# Altium schematic sheet Sheet1.SchDoc
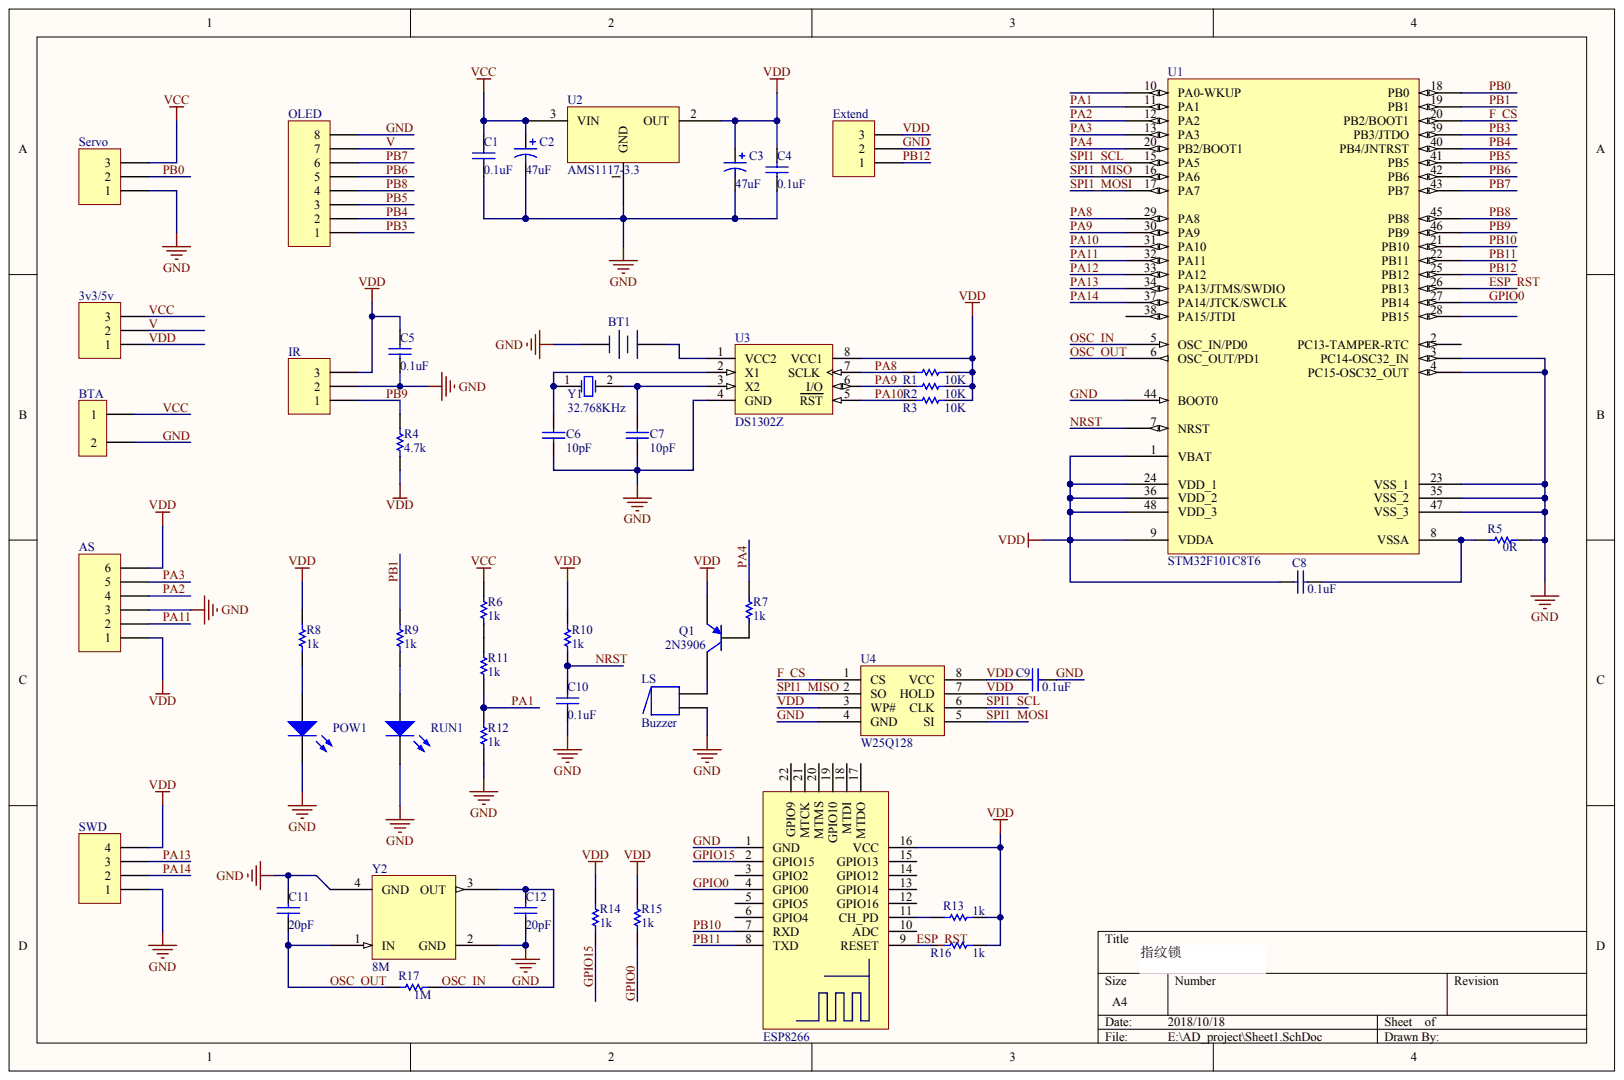
[diagram p0: Sheet1.SchDoc]

## Parts
1  ESP8266
3v3/5v  (no value)
AS  ATK-AS608  (value not drawn)
BT1  Battery  (value not drawn)
BTA  BTA
C1  Öµ: 0.1uF  (hidden comment: Cap 1)
C2  value 47uF  (hidden comment: Cap Pol3)
C3  value 47uF  (hidden comment: Cap Pol3)
C4  Öµ: 0.1uF  (hidden comment: Cap 1)
C5  Öµ: 0.1uF
C6  Öµ: 10pF  (hidden comment: Cap 1)
C7  Öµ: 10pF  (hidden comment: Cap 1)
C8  Öµ: 0.1uF  (hidden comment: Cap 1)
C9  Öµ: 0.1uF  (hidden comment: Cap 1)
C10  Öµ: 0.1uF
C11  Öµ: 20pF
C12  Öµ: 20pF
Extend  (no value)
IR  红外接收  (value not drawn)
LS  Buzzer
OLED  OLED
POW1  LED  (value not drawn)
Q1  2N3906
R1  Öµ: 10K  (hidden comment: Res 1)
R2  Öµ: 10K  (hidden comment: Res 1)
R3  Öµ: 10K  (hidden comment: Res 1)
R4  Öµ: 4.7k  (hidden comment: Res 1)
R5  Öµ: 0R  (hidden comment: Res 1)
R6  Öµ: 1k  (hidden comment: Res 1)
R7  Öµ: 1k  (hidden comment: Res 1)
R8  Öµ: 1k  (hidden comment: Res 1)
R9  Öµ: 1k  (hidden comment: Res 1)
R10  Öµ: 1k  (hidden comment: Res 1)
R11  Öµ: 1k  (hidden comment: Res 1)
R12  Öµ: 1k  (hidden comment: Res 1)
R13  Öµ: 1k  (hidden comment: Res 1)
R14  Öµ: 1k  (hidden comment: Res 1)
R15  Öµ: 1k  (hidden comment: Res 1)
R16  Öµ: 1k  (hidden comment: Res 1)
R17  Öµ: 1M  (hidden comment: Res 1)
RUN1  LED  (value not drawn)
Servo  (no value)
SWD  SWD
U1  STM32F101C8T6
U2  AMS1117-3.3
U3  DS1302Z
U4  W25Q128
Y1  Öµ: 32.768KHz  (hidden comment: XTAL)
Y2  8M

## Nets
ESP_RST: 1.9(RESET) R16.1 U1.26(PB13)
F_CS: U1.20 U4.1(CS)
GND: 1.1(GND) AS.3 BT1.2 BTA.2 C1.1 C2.2 C3.2 C4.1 C5.2 C6.1 C7.1 C9.2 C10.2 C11.1 C12.2 Extend.2 IR.2 LS.2 OLED.8 POW1.2 R5.2 R12.2 RUN1.2 Servo.1 SWD.1 U1.3(PC14-OSC32_IN) U1.4(PC15-OSC32_OUT) U1.23(VSS_1) U1.35(VSS_2) U1.44(BOOT0) U1.47(VSS_3) U2.1 U3.4(GND) U4.4(GND) Y2.2(GND) Y2.4(GND)
GPIO0: 1.4(GPIO0) R15.2 U1.27(PB14)
GPIO15: 1.2(GPIO15) R14.2
NRST: C10.1 R10.2 U1.7(NRST)
OSC_IN: C12.1 R17.2 U1.5(OSC_IN/PD0) Y2.3(OUT)
OSC_OUT: C11.2 R17.1 U1.6(OSC_OUT/PD1) Y2.1(IN)
PA1: R11.2 R12.1 U1.11(PA1)
PA2: AS.4 U1.12(PA2)
PA3: AS.5 U1.13(PA3)
PA4: R7.1 U1.20
PA8: R1.1 U1.29(PA8) U3.7(SCLK)
PA9: R2.1 U1.30(PA9) U3.6(I/O)
PA10: R3.1 U1.31(PA10) U3.5(RST)
PA11: AS.2 U1.32(PA11)
PA12: U1.33(PA12)
PA13: SWD.3 U1.34(PA13/JTMS/SWDIO)
PA14: SWD.2 U1.37(PA14/JTCK/SWCLK)
PB0: Servo.2 U1.18(PB0)
PB1: R9.1 U1.19(PB1)
PB3: OLED.1 U1.39(PB3/JTDO)
PB4: OLED.2 U1.40(PB4/JNTRST)
PB5: OLED.3 U1.41(PB5)
PB6: OLED.5 U1.42(PB6)
PB7: OLED.6 U1.43(PB7)
PB8: OLED.4 U1.45(PB8)
PB9: IR.1 R4.1 U1.46(PB9)
PB10: 1.7(RXD) U1.21(PB10)
PB11: 1.8(TXD) U1.22(PB11)
PB12: Extend.1 U1.25(PB12)
SPI1_MISO: U1.16(PA6) U4.2(SO)
SPI1_MOSI: U1.17(PA7) U4.5(SI)
SPI1_SCL: U1.15(PA5) U4.6(CLK)
V: 3v3/5v.2 OLED.7
VCC: 3v3/5v.3 BTA.1 C1.2 C2.1 R6.1 Servo.3 U2.3
VDD: 1.16(VCC) 3v3/5v.1 AS.1 AS.6 C3.1 C4.2 C5.1 C8.1 C9.1 Extend.3 IR.3 Q1.1 R1.2 R2.2 R3.2 R4.2 R8.1 R10.1 R13.2 R14.1 R15.1 R16.2 SWD.4 U1.1(VBAT) U1.9(VDDA) U1.24(VDD_1) U1.36(VDD_2) U1.48(VDD_3) U2.2 U3.8(VCC1) U4.3(WP#) U4.7(HOLD) U4.8(VCC)
Net1_11: 1.11(CH_PD) R13.1
NetBT1_1: BT1.1 U3.1(VCC2)
NetC6_2: C6.2 U3.2(X1) Y1.1
NetC7_2: C7.2 U3.3(X2) Y1.2
NetC8_2: C8.2 R5.1 U1.8(VSSA)
NetLS_1: LS.1 Q1.3
NetPOW1_1: POW1.1 R8.2
NetQ1_2: Q1.2 R7.2
NetR6_2: R6.2 R11.1
NetR9_2: R9.2 RUN1.1

Not connected: 1 3(GPIO2) 5(GPIO5) 6(GPIO4) 10(ADC) 12(GPIO16) 13(GPIO14) 14(GPIO12) 15(GPIO13) 17(MTDO) 18(MTDI) 19(GPIO10) 20(MTMS) 21(MTCK) 22(GPIO9); U1 2(PC13-TAMPER-RTC) 10(PA0-WKUP) 28(PB15) 38(PA15/JTDI)

## Library data of the parts
POW1 RUN1 = (no symbol name): Öµ: GRN
U2 = (no symbol name): 封装: SOT-223
1 3v3/5v AS BT1 BTA C1-C12 Extend IR LS OLED Q1 R1-R17 Servo SWD U1 U3 U4 Y1 Y2 = (no symbol name)
  3v3/5v AS BTA Extend IR OLED Servo SWD: LatestRevisionDate: 17-Jul-2002; LatestRevisionNote: Re-released for DXP Platform.
  C2 C3: Published: 8-Jun-2000; LatestRevisionDate: 29-May-2009; LatestRevisionNote: IPC-7351 Footprint Added.; PackageReference: C0805; PackageDescription: Chip Capacitor
  LS: Published: 24-Mar-1999; LatestRevisionDate: 17-Dec-2003; LatestRevisionNote: Schematic revised, PCB Footprint replaced.; DatasheetVersion: 17-Dec-2003; PackageReference: ABSM-1574; PackageDescription: Magnetic Transducer Buzzer; ComponentLink1Description: Manufacturer Link; ComponentLink1URL: http://www.megastar.com/linecard/buzzmode/index.php
  Q1: Published: 8-Jun-2000; LatestRevisionDate: 15-Jan-2003; LatestRevisionNote: PCB Footprint 'BCY-W3/D4.7' replaced; DatasheetVersion: 1997; PackageVersion: Sep-1998; PackageReference: TO-92A; PackageDescription: TO, Flat Index; 3 In-Line, Axial Leads; Body Dia. 4.5mm; Leads 0.51 x 0.48 mm (max); ComponentLink1Description: Manufacturer Link; ComponentLink1URL: http://www.fairchildsemi.com/; ComponentLink2Description: Datasheet; ComponentLink2URL: http://www.fairchildsemi.com/ds/2N%2F2N3906.pdf
  U1: Published: 30-May-2008; DatasheetVersion: rev6, Mar-2008; PackageVersion: Mar-2008; PackageReference: LQFP48; PackageDescription: 48-Pin Low-Profile Quad Flat Pack, 7 x 7 mm Body, 0.5 mm Pitch (LQFP48); ComponentLink1Description: Manufacturer Link; ComponentLink1URL: www.st.com/stm32; ComponentLink2Description: Datasheet; ComponentLink2URL: http://www.st.com/stonline/products/literature/ds/13586.pdf
  U3: : DS1302
  3v3/5v AS BTA C2 C3 Extend IR LS OLED Q1 Servo SWD U1: Publisher: Altium Limited
